# Altium schematic sheet Shunt amplifier.SchDoc
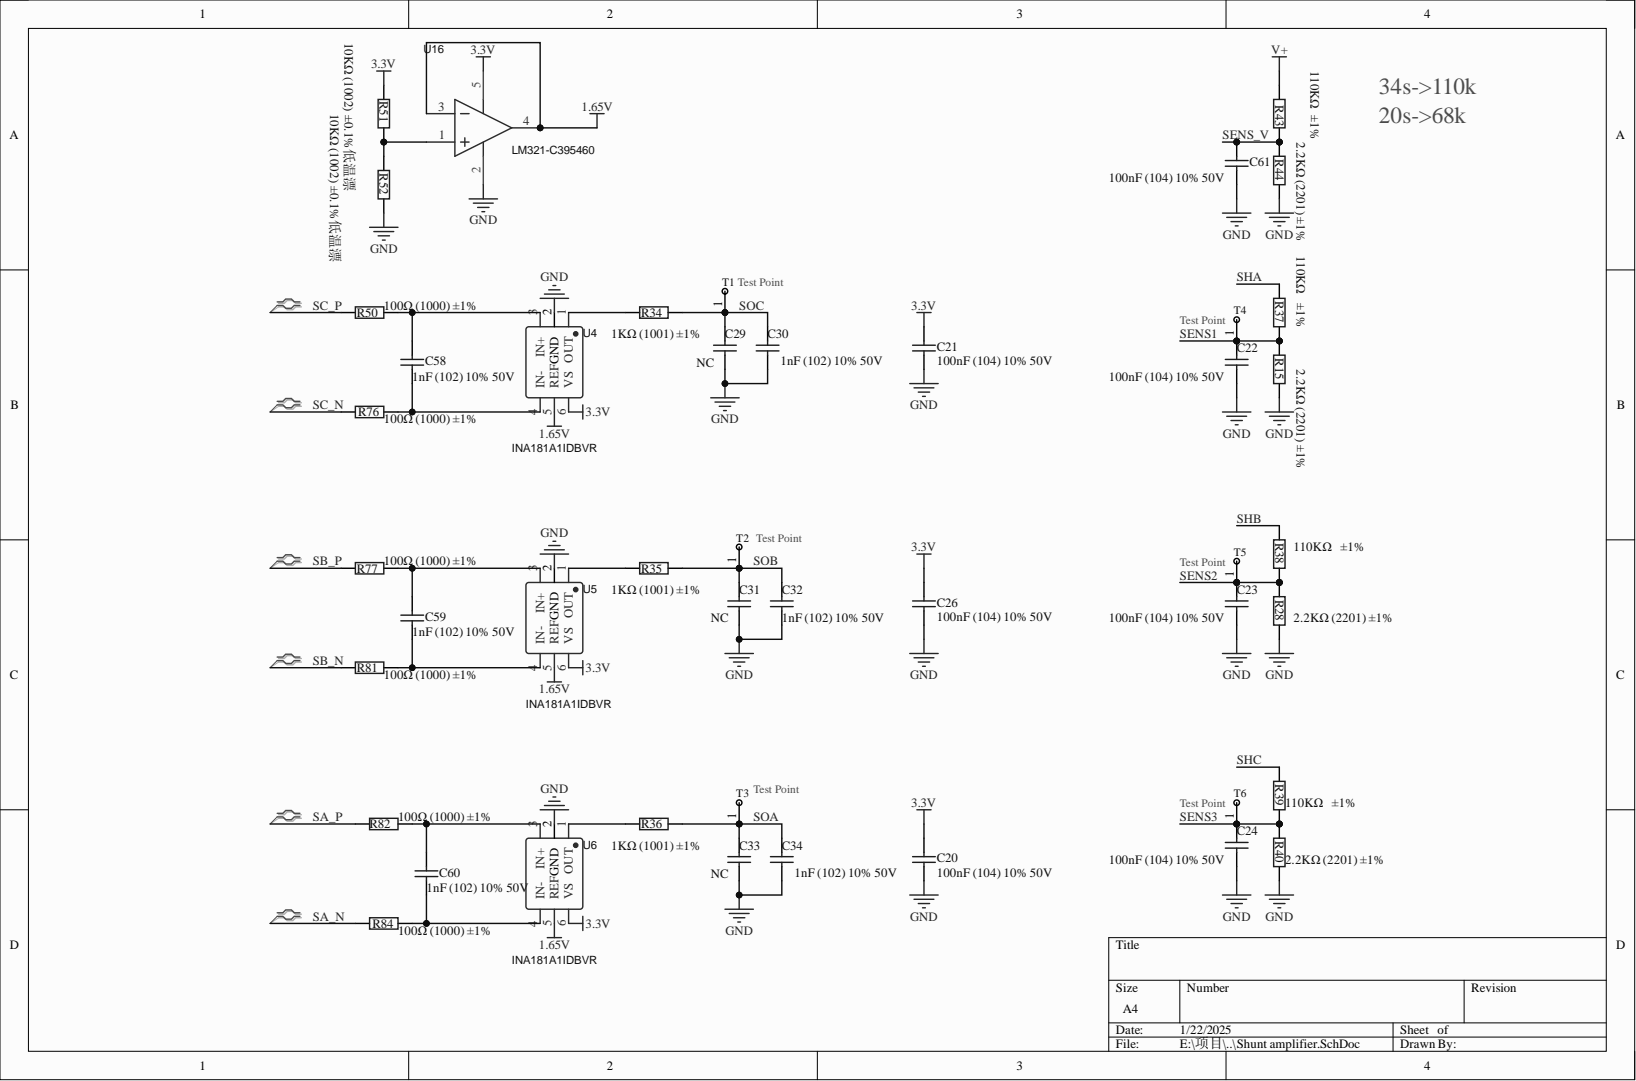
[diagram p0: Shunt amplifier.SchDoc]

## Parts
C20  100nF (104) 10% 50V  [0603_C]
C21  100nF (104) 10% 50V  [0603_C]
C22  100nF (104) 10% 50V  [0603_C]
C23  100nF (104) 10% 50V  [0603_C]
C24  100nF (104) 10% 50V  [0603_C]
C26  100nF (104) 10% 50V  [0603_C]
C29  NC  [0603_C]
C30  1nF (102) 10% 50V  [0603_C]
C31  NC  [0603_C]
C32  1nF (102) 10% 50V  [0603_C]
C33  NC  [0603_C]
C34  1nF (102) 10% 50V  [0603_C]
C58  1nF (102) 10% 50V  [0603_C]
C59  1nF (102) 10% 50V  [0603_C]
C60  1nF (102) 10% 50V  [0603_C]
C61  100nF (104) 10% 50V  [0603_C]
R15  2.2KΩ (2201) ±1%  [0603_R]
R28  2.2KΩ (2201) ±1%  [0603_R]
R34  1KΩ (1001) ±1%  [0603_R]
R35  1KΩ (1001) ±1%  [0603_R]
R36  1KΩ (1001) ±1%  [0603_R]
R37  110KΩ   ±1%  [0603_R]
R38  110KΩ   ±1%  [0603_R]
R39  110KΩ   ±1%  [0603_R]
R40  2.2KΩ (2201) ±1%  [0603_R]
R43  110KΩ  ±1%  [0603_R]
R44  2.2KΩ (2201) ±1%  [0603_R]
R50  100Ω (1000) ±1%  [0603_R]
R51  10KΩ (1002) ±0.1%低温漂  [0603_R]
R52  10KΩ (1002) ±0.1%低温漂  [0603_R]
R76  100Ω (1000) ±1%  [0603_R]
R77  100Ω (1000) ±1%  [0603_R]
R81  100Ω (1000) ±1%  [0603_R]
R82  100Ω (1000) ±1%  [0603_R]
R84  100Ω (1000) ±1%  [0603_R]
T1  Test Point  [TESTPOINT_1MM - small]
T2  Test Point  [TESTPOINT_1MM - small]
T3  Test Point  [TESTPOINT_1MM - small]
T4  Test Point  [TESTPOINT_1MM - small]
T5  Test Point  [TESTPOINT_1MM - small]
T6  Test Point  [TESTPOINT_1MM - small]
U4  INA181A1IDBVR  [SOT-23-6_L2.9-W1.6-P0.95-LS2.8-TL]
U5  INA181A1IDBVR  [SOT-23-6_L2.9-W1.6-P0.95-LS2.8-TL]
U6  INA181A1IDBVR  [SOT-23-6_L2.9-W1.6-P0.95-LS2.8-TL]
U16  LM321-C395460  [SOT-23-5_L3.0-W1.7-P0.95-LS2.8-BL]

## Nets
1.65V: U4.5(REF) U5.5(REF) U6.5(REF) U16.3 U16.4
3.3V: C20.1 C21.1 C26.1 R51.2 U4.6(VS) U5.6(VS) U6.6(VS) U16.5
GND: C20.2 C21.2 C22.2 C23.2 C24.2 C26.2 C29.1 C30.1 C31.1 C32.1 C33.1 C34.1 C61.2 R15.1 R28.1 R40.1 R44.1 R52.1 U4.2(GND) U5.2(GND) U6.2(GND) U16.2
V+: R43.2
SA_N: R84.1
SA_P: R82.1
SB_N: R81.1
SB_P: R77.1
SC_N: R76.1
SC_P: R50.1
SENS1: C22.1 R15.2 R37.1 T4.1
SENS2: C23.1 R28.2 R38.1 T5.1
SENS3: C24.1 R39.1 R40.2 T6.1
SENS_V: C61.1 R43.1 R44.2
SHA: R37.2
SHB: R38.2
SHC: R39.2
SOA: C33.2 C34.2 R36.2 T3.1
SOB: C31.2 C32.2 R35.2 T2.1
SOC: C29.2 C30.2 R34.2 T1.1
NetC58_1: C58.1 R76.2 U4.4(IN-)
NetC58_2: C58.2 R50.2 U4.3(IN+)
NetC59_1: C59.1 R81.2 U5.4(IN-)
NetC59_2: C59.2 R77.2 U5.3(IN+)
NetC60_1: C60.1 R84.2 U6.4(IN-)
NetC60_2: C60.2 R82.2 U6.3(IN+)
NetR34_1: R34.1 U4.1(OUT)
NetR35_1: R35.1 U5.1(OUT)
NetR36_1: R36.1 U6.1(OUT)
NetR51_1: R51.1 R52.2 U16.1

## Library data of the parts
C20-C24 C26 C61 = 0603 100nF (104) 10% 50V: 贴片电容; Component Kind: Standard; ComponentLink1Description: 供应商链接; ComponentLink1URL: http://www.szlcsc.com/product/details_15331.html; Library Name: Cap.SchLib; Package: 0603; Supplier: LC; SuppliersPartNumber: C14663
C29 C31 C33 = 0603 15nF (153) 10% 50V: 贴片电容; Component Kind: Standard; ComponentLink1Description: 供应商链接; ComponentLink1URL: http://www.szlcsc.com/product/details_1948.html; Library Name: Cap.SchLib; Package: 0603; Supplier: LC; SuppliersPartNumber: C1596
C30 C32 C34 C58-C60 = 0402 1nF (102) 10% 50V: 贴片电容; Component Kind: Standard; ComponentLink1Description: 供应商链接; ComponentLink1URL: http://www.szlcsc.com/product/details_1875.html; Library Name: Cap.SchLib; Package: 0402; Supplier: LC; SuppliersPartNumber: C1523
R15 R28 R40 R44 = 0603 2.2KΩ (2201) 1%: 贴片电阻; Component Kind: Standard; ComponentLink1Description: 供应商链接; ComponentLink1URL: http://www.szlcsc.com/product/details_4597.html; Library Name: RES.SchLib; Package: 0603; Supplier: LC; SuppliersPartNumber: C4190
R34-R36 = 0402 1KΩ (1001) 1%: 贴片电阻; Component Kind: Standard; ComponentLink1Description: 供应商链接; ComponentLink1URL: http://www.szlcsc.com/product/details_12256.html; Library Name: RES.SchLib; Package: 0402; Supplier: LC; SuppliersPartNumber: C11702
R37-R39 R43 = 0402 56KΩ (5602) 1%: 贴片电阻; Component Kind: Standard; ComponentLink1Description: 供应商链接; ComponentLink1URL: http://www.szlcsc.com/product/details_26539.html; Library Name: RES.SchLib; Package: 0402; Supplier: LC; SuppliersPartNumber: C25796
R50 R76 R77 R81 R82 R84 = 0603 100Ω (1000) 1%: 贴片电阻; Component Kind: Standard; ComponentLink1Description: 供应商链接; ComponentLink1URL: http://www.szlcsc.com/product/details_23502.html; Library Name: RES.SchLib; Package: 0603; Supplier: LC; SuppliersPartNumber: C22775
R51 R52 = 0603 10KΩ (1002) 1%: 贴片电阻; Component Kind: Standard; ComponentLink1Description: 供应商链接; ComponentLink1URL: http://www.szlcsc.com/product/details_26547.html; Library Name: RES.SchLib; Package: 0603; Supplier: LC; SuppliersPartNumber: C25804
T1-T6 = U: Component Kind: Standard
U4-U6 = INA181A1IDBVR: BOM_Manufacturer: TI(德州仪器); Contributor: LCSC; BOM_JLCPCB Part Class: Extended Part; BOM_Manufacturer Part: INA181A1IDBVR; BOM_Supplier: LCSC; BOM_Supplier Part: C2058943; Component Kind: Standard; spicePre: U; spiceSymbolName: INA181A1IDBVR
U16 = LM321-C395460: BOM_Manufacturer: IDCHIP; BOM_Manufacturer Part: LM321; Contributor: LCSC; BOM_JLCPCB Part Class: Extended Part; BOM_Supplier: LCSC; BOM_Supplier Part: C395460; Component Kind: Standard; spicePre: U; spiceSymbolName: LM321-C395460
